# Altium schematic sheet charging.SchDoc
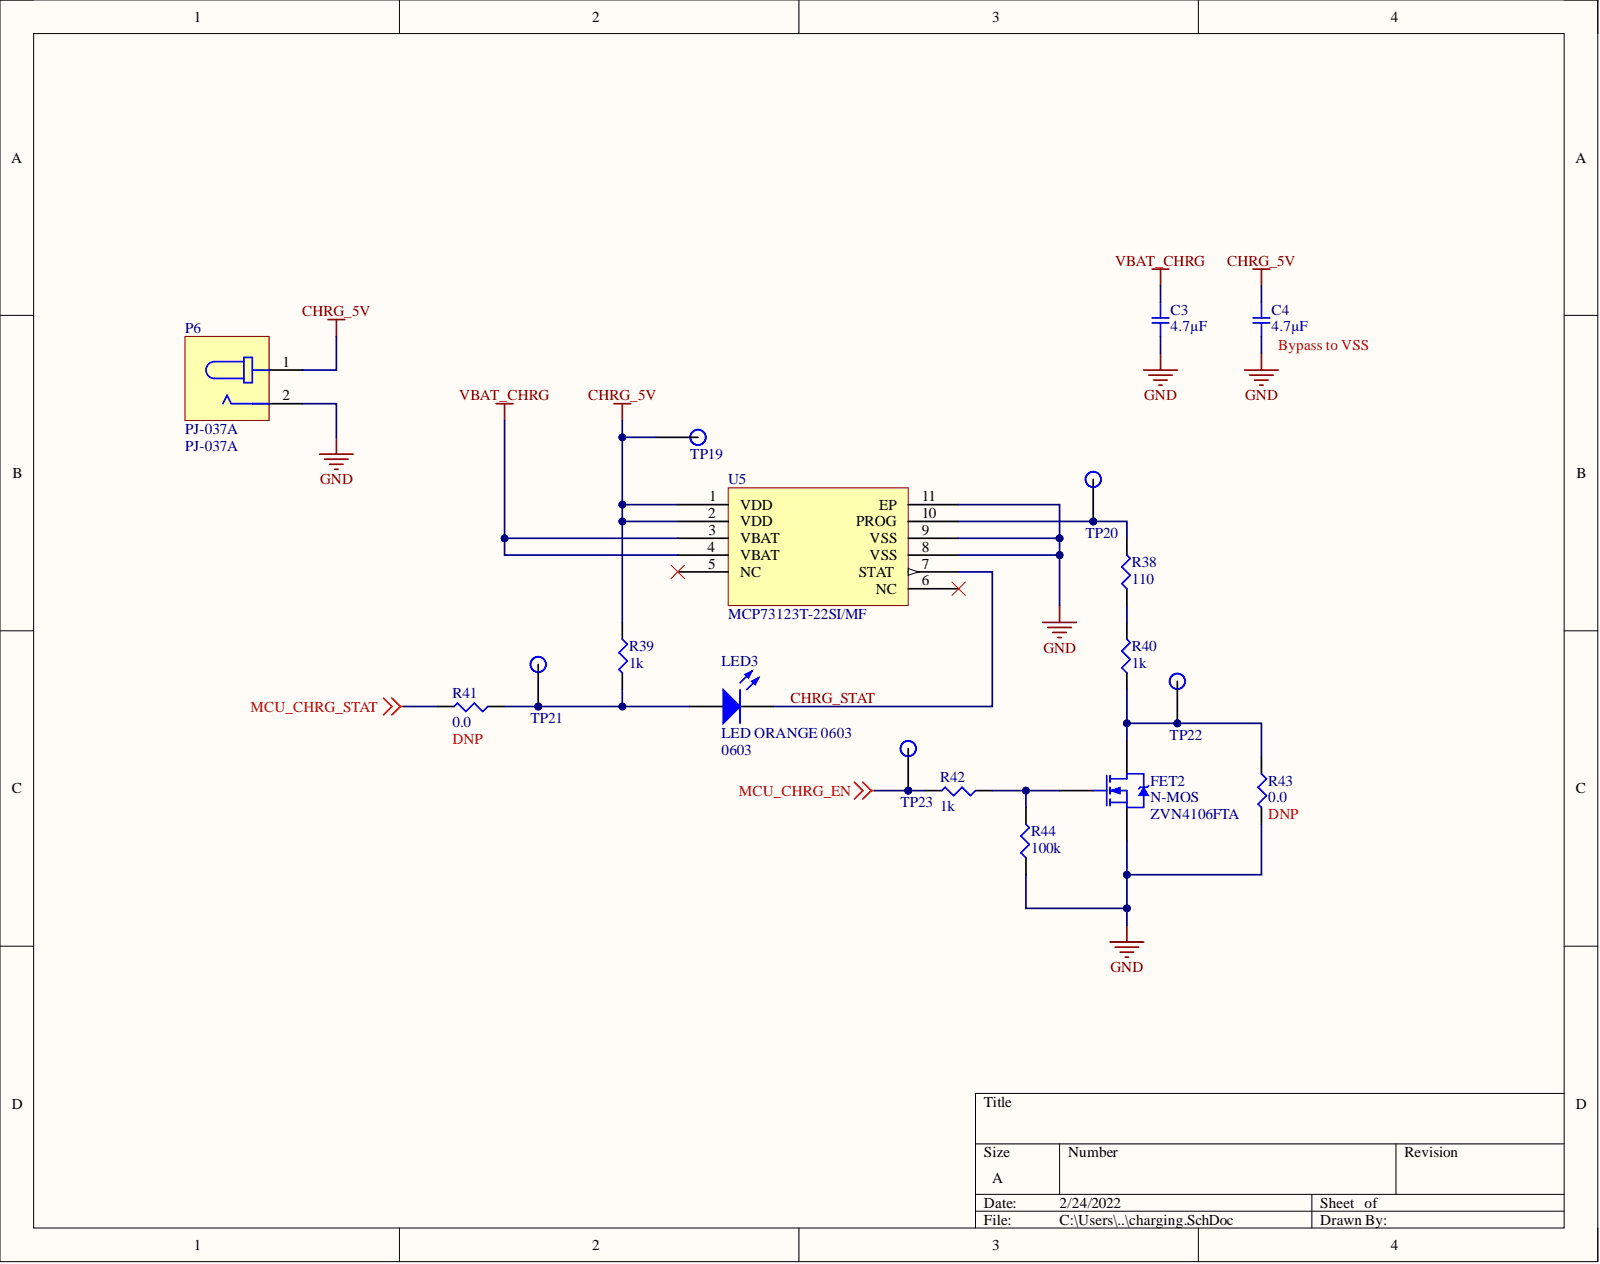
[diagram p0: charging.SchDoc]

## Parts
C3  4.7µF  [SMD-0805C]
C4  4.7µF  [SMD-0805C]
FET2  N-MOS  Manufacturer P/N: ZVN4106FTA  [SOT95P240X110-3N]
LED3  LED ORANGE 0603  Color: ORANGE  Package / Case: 0603  [LED 0603 ORANGE]
P6  PJ-037A  [CUI_PJ-037A]
R38  110  [SMD-0805-RES]
R39  1k  [SMD-0805-RES]
R40  1k  [SMD-0805-RES]
R41  0.0  [SMD-0805-RES]
R42  1k  [SMD-0805-RES]
R43  0.0  [SMD-0805-RES]
R44  100k  [SMD-0805-RES]
TP19  TP  [Test Point]
TP20  TP  [Test Point]
TP21  TP  [Test Point]
TP22  TP  [Test Point]
TP23  TP  [Test Point]
U5  MCP73123T-22SI/MF  [DFN10_3x3MC]

## Nets
CHRG_5V: C4.1 P6.1 R39.1 TP19.1 U5.1(VDD) U5.2(VDD)
GND: C3.2 C4.2 FET2.3 P6.2 R43.2 R44.1 U5.8(VSS) U5.9(VSS) U5.11(EP)
MCU_CHRG_EN: R42.2 TP23.1
MCU_CHRG_STAT: R41.2
VBAT_CHRG: C3.1 U5.3(VBAT) U5.4(VBAT)
CHRG_STAT: LED3.2 U5.7(STAT)
NetFET2_1: FET2.1 R42.1 R44.2
NetFET2_2: FET2.2 R40.1 R43.1 TP22.1
NetLED3_1: LED3.1 R39.2 R41.1 TP21.1
NetR38_1: R38.1 R40.2
NetR38_2: R38.2 TP20.1 U5.10(PROG)

Not connected: U5 5(NC) 6(NC)

## Library data of the parts
C3 C4 = GCJ21BR71C475KA01L: CAP CER 4.7UF 16V X7R 0805; Manufacturer: Murata Electronics North America; @ qty: 0; Minimum Quantity: 1; Tolerance: ±10%; Unit Price (USD): 0.44; Applications: Automotive, Boardflex Sensitive; Component Kind: Standard; Datasheets: http://www.murata.com/~/media/webrenewal/support/library/catalog/products/capacitor/mlcc/c03e.ashx; Digi-Key Part Number: 490-10557-1-ND; Factory Stock: 0; Features: Soft Termination; Height - Seated (Max): -; Image: //media.digikey.com/Renders/Murata%20Renders/0805%20(2012%20Metric)%20.85mm.jpg; Lead Spacing: -; Lead Style: -; Library Name: capacitors.SchLib; Mounting Type: Surface Mount, MLCC; Operating Temperature: -55°C ~ 125°C; Package / Case: 0805 (2012 Metric); Packaging: Cut Tape (CT); Part Number: GCJ21BR71C475KA01L; Part Status: Active; Quantity Available: 53217; Ratings: AEC-Q200; Series: GCJ; Size / Dimension: 0.079" L x 0.049" W (2.00mm x 1.25mm); Temperature Coefficient: X7R; Thickness (Max): 0.057" (1.45mm); Value: 4.7µF; Voltage: 16V
FET2 = N-MOS Enhancement Mode: MOSFET N-CH 60V 200MA SOT23-3; Manufacturer: Zetex; Component Kind: Standard; Digikey P/N: ZVN4106FCT-ND; Library Name: misc.SchLib
LED3 = LED ORANGE 0603: A-0603UOC; Manufacturer: Lighthouse LEDs; Minimum Quantity: 1; Availability: In Stock; Component Kind: Standard; ComponentLink1Description: Manufacturer; ComponentLink1URL: https://lighthouseleds.com/0603-smd-led-orange-ultra-bright-170-mcd.html; Datasheets: https://lighthouseleds.com/downloads/dl/file/id/11/0603_orange_amber_smd_led_datasheet.pdf; Library Name: misc.SchLib; Manufacturer P/N: A-0603UOC
P6 = PJ-037A: CONN PWR JACK 2X5.5MM SOLDER; Manufacturer: CUI Devices; Component Kind: Standard; Library Name: connector.SchLib; Manufacturer P/N: PJ-037A; Supplier: Digi-Key; Supplier P/N: CP-037A-ND
R38 = ERJ-6ENF1100V: RES SMD 110 OHM 1% 1/8W 0805; Manufacturer: Panasonic Electronic Components; @ qty: 1500; Factory Stock: 0; Minimum Quantity: 1; Power (Watts): 0.125W, 1/8W; Temperature Coefficient: ±100ppm/°C; Unit Price (USD): 0.00729; Component Kind: Standard; Composition: Thick Film; Datasheets: http://industrial.panasonic.com/www-cgi/jvcr13pz.cgi?E+PZ+3+AOA0002+ERJ6ENF1100V+7+WW; Digi-Key Part Number: P110CCT-ND; Failure Rate: -; Features: Automotive AEC-Q200; Height - Seated (Max): 0.028" (0.70mm); Image: //media.digikey.com/Renders/Panasonic%20Renders/ERJ-0805%20Pkg.jpg; Library Name: resistors.SchLib; Number of Terminations: 2; Operating Temperature: -55°C ~ 155°C; Package / Case: 0805 (2012 Metric); Packaging: Cut Tape (CT); Part Number: ERJ-6ENF1100V; Part Status: Active; Quantity Available: 38717; Series: ERJ; Size / Dimension: 0.079" L x 0.049" W (2.00mm x 1.25mm); Supplier Device Package: 0805; Tolerance: ±1%; Value: 110
R39 R40 R42 = ERJ-P06J102V: RES SMD 1K OHM 5% 1/2W 0805; Manufacturer: Panasonic Electronic Components; @ qty: 1500; Factory Stock: 0; Minimum Quantity: 1; Power (Watts): 0.5W, 1/2W; Temperature Coefficient: ±200ppm/°C; Unit Price (USD): 0.01839; Component Kind: Standard; Composition: Thick Film; Datasheets: https://industrial.panasonic.com/cdbs/www-data/pdf/RDA0000/AOA0000C243.pdf; Digi-Key Part Number: P1.0KADCT-ND; Failure Rate: -; Features: Automotive AEC-Q200, Pulse Withstanding; Height - Seated (Max): 0.028" (0.70mm); Image: //media.digikey.com/Renders/Panasonic%20Renders/ERJ-0805%20Pkg.jpg; Library Name: resistors.SchLib; Number of Terminations: 2; Operating Temperature: -55°C ~ 155°C; Package / Case: 0805 (2012 Metric); Packaging: Cut Tape (CT); Part Number: ERJ-P06J102V; Part Status: Active; Quantity Available: 124757; Series: ERJ; Size / Dimension: 0.079" L x 0.049" W (2.00mm x 1.25mm); Supplier Device Package: 0805; Tolerance: ±5%; Value: 1k
R41 R43 = ERJ-6GEY0R00V: RES SMD 0.0 OHM JUMPER 1/8W 0805; Manufacturer: Panasonic Electronic Components; @ qty: 1500; Factory Stock: 0; Minimum Quantity: 1; Power (Watts): 0.125W, 1/8W; Unit Price (USD): 0.00544; Component Kind: Standard; Composition: Thick Film; Datasheets: http://industrial.panasonic.com/www-cgi/jvcr13pz.cgi?E+PZ+3+AOA0001+ERJ6GEY0R00V+7+WW; Digi-Key Part Number: P0.0ACT-ND; DNP: DNP; Failure Rate: -; Features: Automotive AEC-Q200; Height - Seated (Max): 0.028" (0.70mm); Image: //media.digikey.com/Renders/Panasonic%20Renders/ERJ-0805%20Pkg.jpg; Library Name: resistors.SchLib; Number of Terminations: 2; Operating Temperature: -55°C ~ 155°C; Package / Case: 0805 (2012 Metric); Packaging: Cut Tape (CT); Part Number: ERJ-6GEY0R00V; Part Status: Active; Quantity Available: 1597627; Series: ERJ; Size / Dimension: 0.079" L x 0.049" W (2.00mm x 1.25mm); Supplier Device Package: 0805; Temperature Coefficient: -; Tolerance: Jumper; Value: 0.0
R44 = ERJ-6ENF1003V: RES SMD 100K OHM 1% 1/8W 0805; Manufacturer: Panasonic Electronic Components; @ qty: 1500; Factory Stock: 0; Minimum Quantity: 1; Power (Watts): 0.125W, 1/8W; Temperature Coefficient: ±100ppm/°C; Unit Price (USD): 0.00729; Component Kind: Standard; Composition: Thick Film; Datasheets: http://industrial.panasonic.com/www-cgi/jvcr13pz.cgi?E+PZ+3+AOA0002+ERJ6ENF1003V+7+WW; Digi-Key Part Number: P100KCCT-ND; Failure Rate: -; Features: Automotive AEC-Q200; Height - Seated (Max): 0.028" (0.70mm); Image: //media.digikey.com/Renders/Panasonic%20Renders/ERJ-0805%20Pkg.jpg; Library Name: resistors.SchLib; Number of Terminations: 2; Operating Temperature: -55°C ~ 155°C; Package / Case: 0805 (2012 Metric); Packaging: Cut Tape (CT); Part Number: ERJ-6ENF1003V; Part Status: Active; Quantity Available: 760677; Series: ERJ; Size / Dimension: 0.079" L x 0.049" W (2.00mm x 1.25mm); Supplier Device Package: 0805; Tolerance: ±1%; Value: 100k
TP19-TP23 = TP: SMD TEST POINT; Component Kind: Standard; Library Name: misc.SchLib
U5 = MCP73123T-22SI/MF: IC BAT CNTRL IRON PHOS 1C 10DFN; Mfr_Name: Microchip; Component Kind: Standard; Digi-Key_Part_Number_1: MCP73123T-22SI/MF-ND; Digi-Key_Part_Number_2: MCP73123T-22SI/MFCT-ND; Digi-Key_Part_Number_3: MCP73123T-22SI/MFDKR-ND; Digi-Key_Part_Number_4: MCP73123T-22SI/MFTR-ND; Library Name: ic.SchLib; Manufacturer_Part_Number: MCP73123T-22SI/MF
